# Altium schematic sheet ГРЛМ.465213.010 Э3.SchDoc
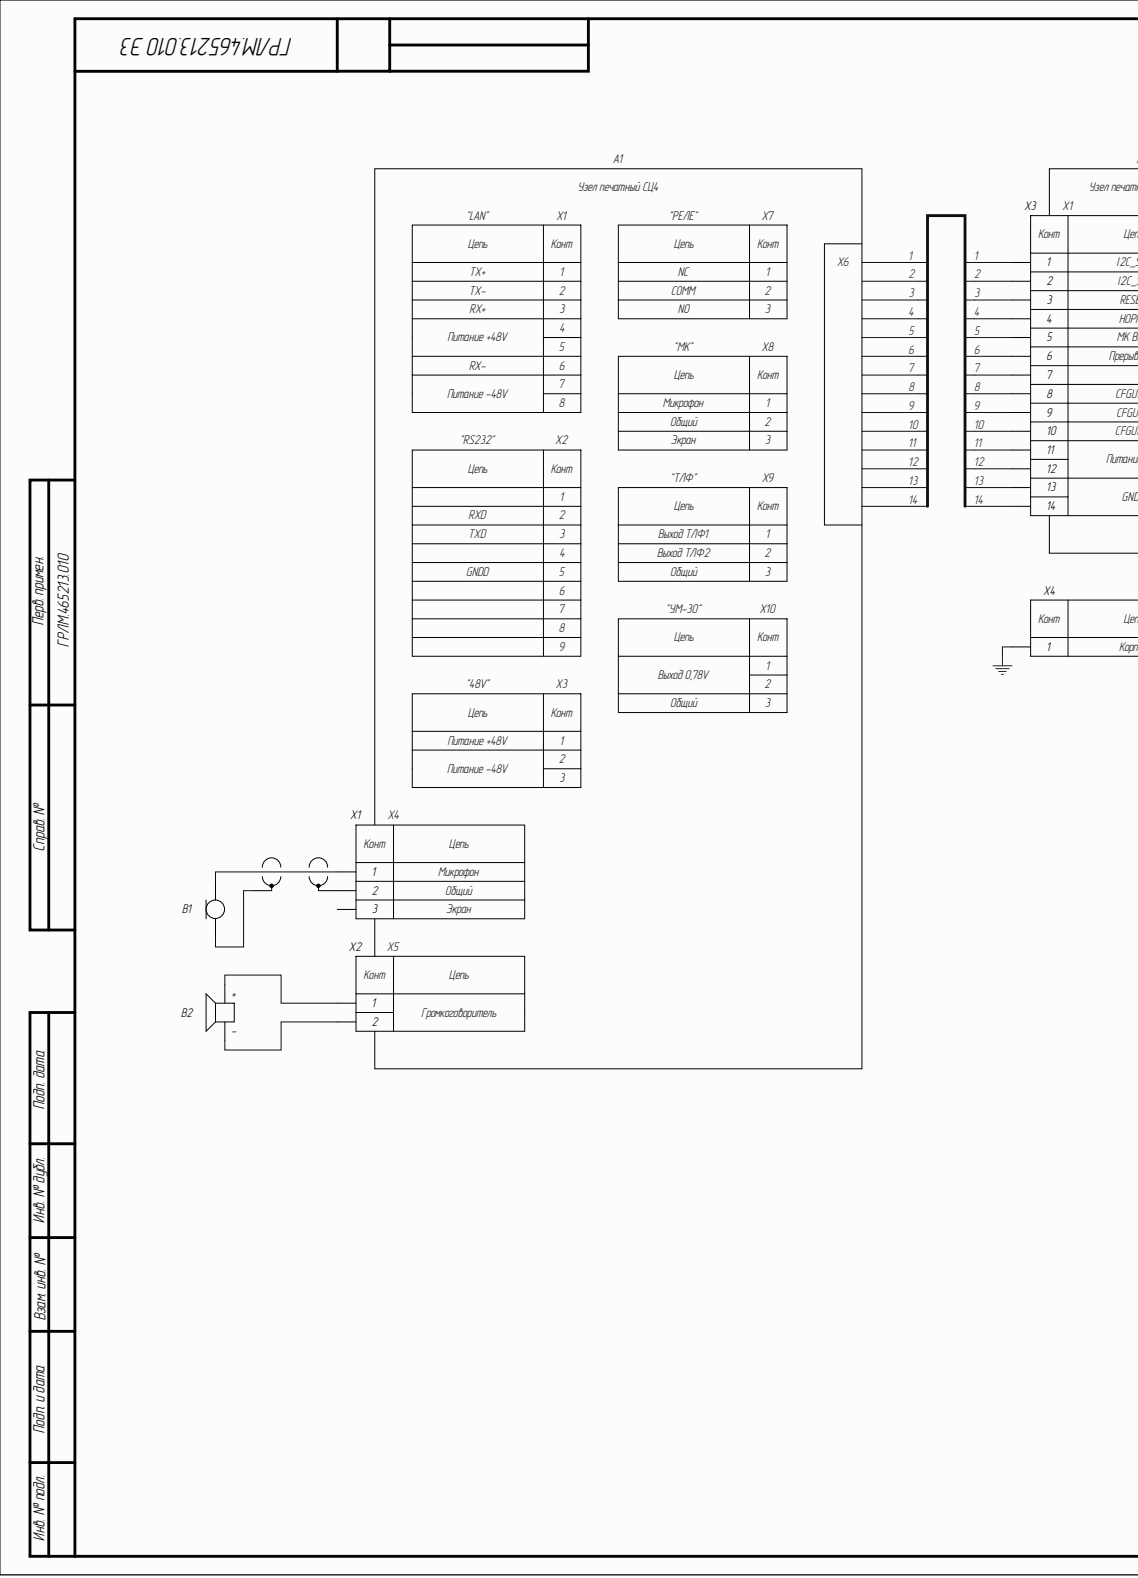
[diagram p0: ГРЛМ.465213.010 Э3.SchDoc, left]
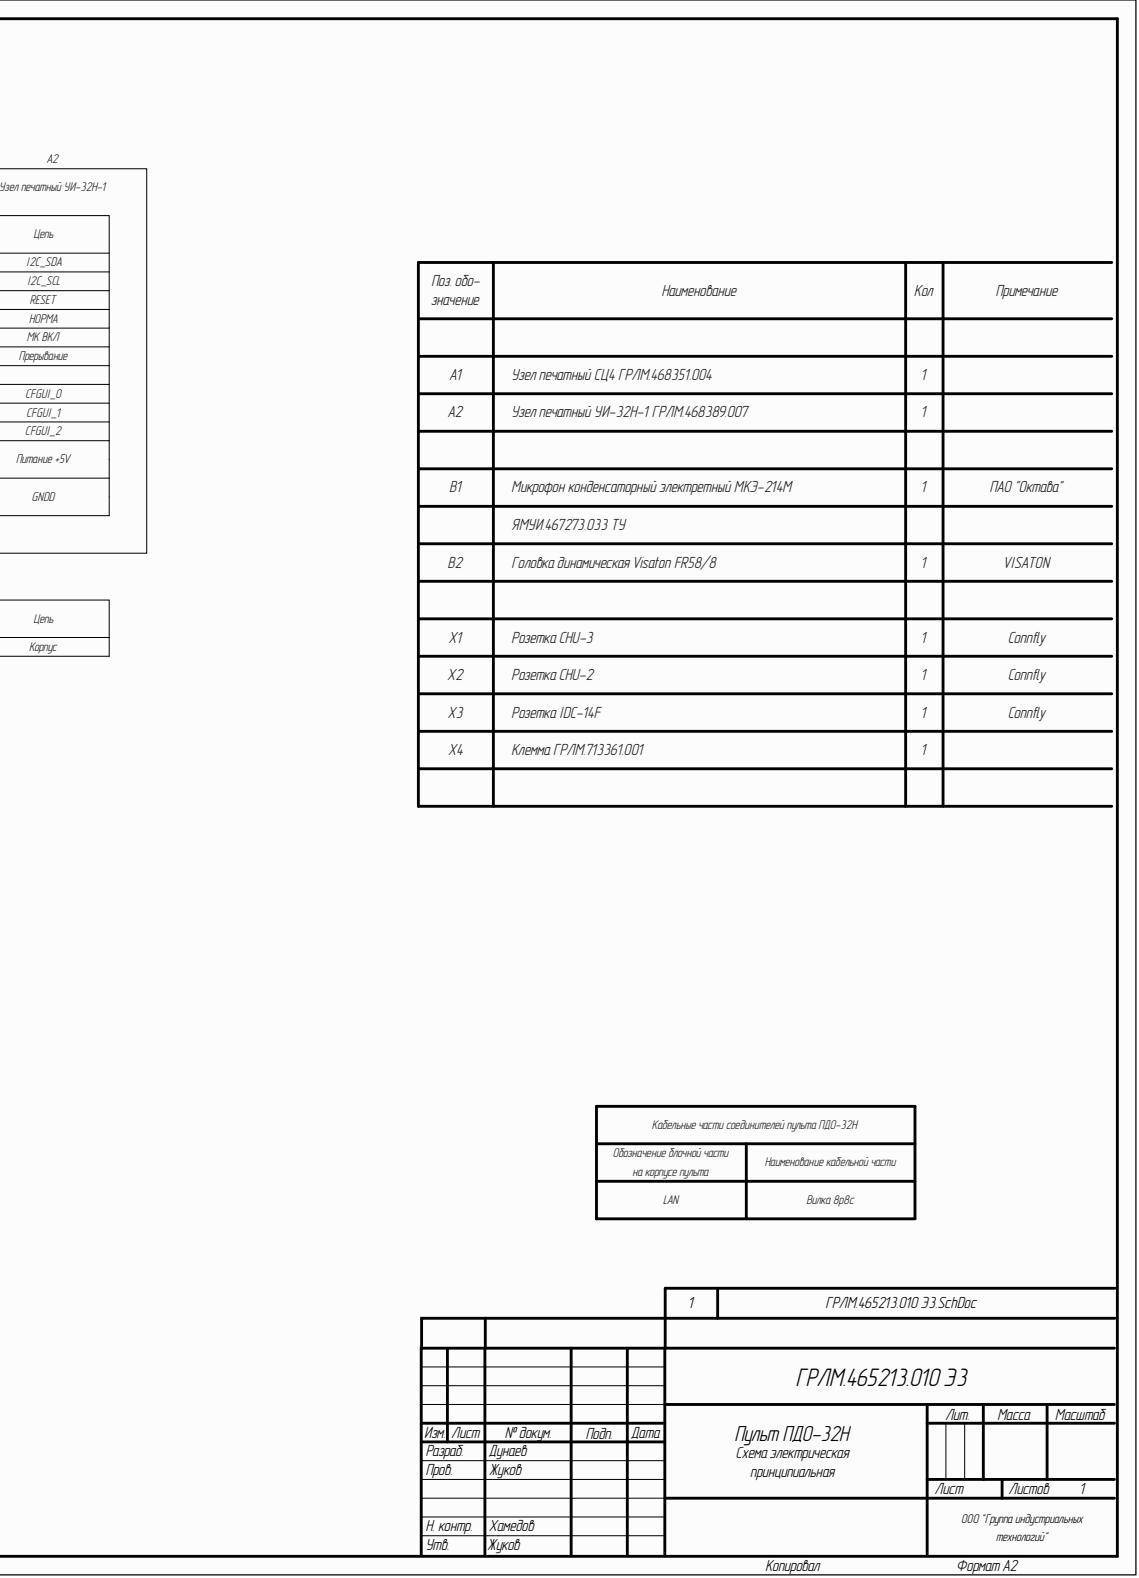
[diagram p1: ГРЛМ.465213.010 Э3.SchDoc, right]

## Parts
B1  EM-6050P  (value not drawn)
B2  28CR08FB1-M50BD  (value not drawn)
X1  CHU-3  (value not drawn)
X2  CHU-2  (value not drawn)
X3  IDC-14F  (value not drawn)
X4  Zazemlenie  (value not drawn)

## Nets
: X4.1
1: X3.1
2: X3.2
3: X3.3
4: X3.4
5: X3.5
6: X3.6
7: X3.7
8: X3.8
9: X3.9
10: X3.10
11: X3.11
12: X3.12
13: X3.13
14: X3.14
NetB1_1: B1.1 X1.1
NetB2_1: B2.1 X2.1
NetB2_2: B2.2 X2.2
N00016:

Not connected: B1 2; X1 2 3

## Library data of the parts
B1 = EM-6050P: Микрофон; Component Kind: Standard; Library Name: Прочее.SchLib
B2 = 28CR08FB1-M50BD: Громкоговоритель 1,5 Вт; Component Kind: Standard; Library Name: Прочее.SchLib
X1 = CHU-3: Розетка; Component Kind: Standard; Library Name: Соединители.SchLib
X2 = CHU-2: Розетка; Component Kind: Standard; Library Name: Соединители.SchLib
X3 = IDC-14F: Розетка; Component Kind: Standard; Library Name: Соединители.SchLib
X4 = Zazemlenie: Клемма заземления; Component Kind: Standard; Library Name: Прочее.SchLib
